# Altium schematic sheet 2_MISC.SchDoc(2_MISC)
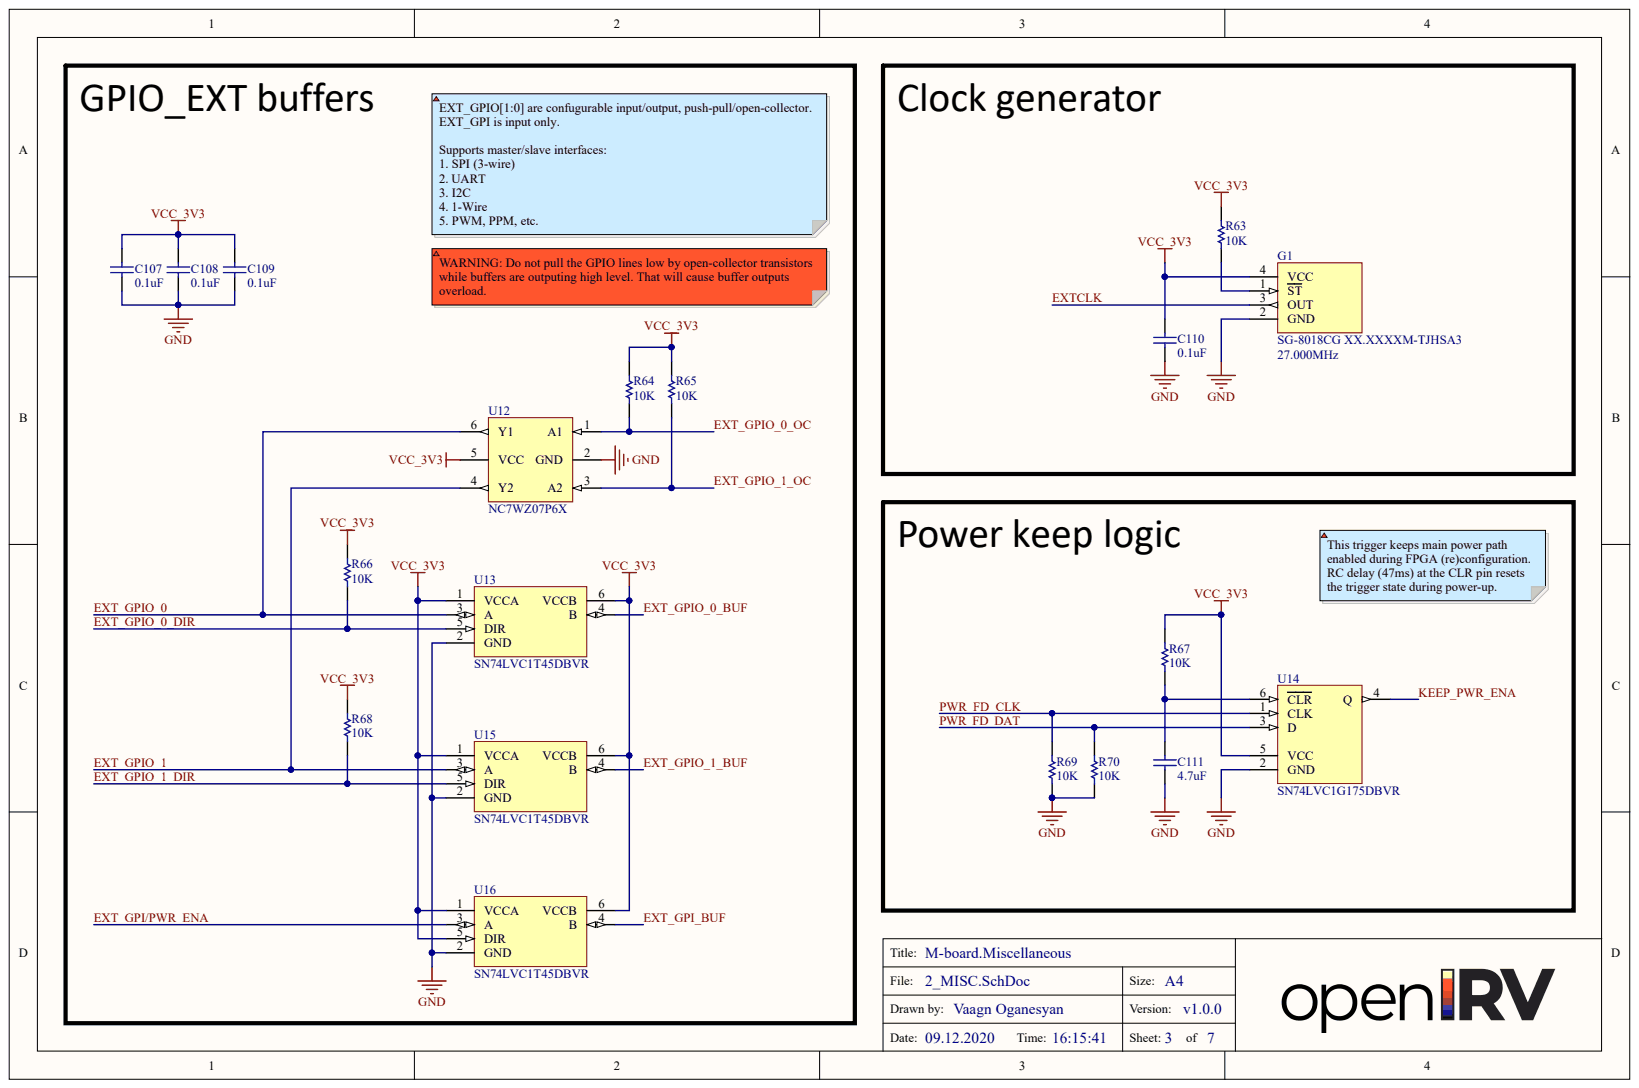
[diagram p0: 2_MISC.SchDoc]

## Parts
C107  0.1uF  [C0402[1005]]
C108  0.1uF  [C0402[1005]]
C109  0.1uF  [C0402[1005]]
C110  0.1uF  [C0402[1005]]
C111  4.7uF  [C0402[1005]]
G1  SG-8018CG XX.XXXXM-TJHSA3  Frequency: 27.000MHz  [SG-8018CG XX.XXXXM-TJHSA3]
R63  10K  [R0402[1005]]
R64  10K  [R0402[1005]]
R65  10K  [R0402[1005]]
R66  10K  [R0402[1005]]
R67  10K  [R0402[1005]]
R68  10K  [R0402[1005]]
R69  10K  [R0402[1005]]
R70  10K  [R0402[1005]]
U12  NC7WZ07P6X  [NC7WZ07P6X]
U13  SN74LVC1T45DBVR  [SN74LVC1T45DBVR]
U14  SN74LVC1G175DBVR  [SN74LVC1G175DBVR]
U15  SN74LVC1T45DBVR  [SN74LVC1T45DBVR]
U16  SN74LVC1T45DBVR  [SN74LVC1T45DBVR]

## Nets
GND: C107.2 C108.2 C109.2 C110.2 C111.2 G1.2(GND) R69.1 R70.1 U12.2(GND) U13.2(GND) U14.2(GND) U15.2(GND) U16.2(GND)
VCC_3V3: C107.1 C108.1 C109.1 C110.1 G1.4(VCC) R63.2 R64.2 R65.2 R66.2 R67.2 R68.2 U12.5(VCC) U13.1(VCCA) U13.6(VCCB) U14.5(VCC) U15.1(VCCA) U15.6(VCCB) U16.1(VCCA) U16.5(DIR) U16.6(VCCB)
EXT_GPI/PWR_ENA: U16.3(A)
EXT_GPI_BUF: U16.4(B)
EXT_GPIO_0: U12.6(Y1) U13.3(A)
EXT_GPIO_0_BUF: U13.4(B)
EXT_GPIO_0_DIR: R66.1 U13.5(DIR)
EXT_GPIO_0_OC: R64.1 U12.1(A1)
EXT_GPIO_1: U12.4(Y2) U15.3(A)
EXT_GPIO_1_BUF: U15.4(B)
EXT_GPIO_1_DIR: R68.1 U15.5(DIR)
EXT_GPIO_1_OC: R65.1 U12.3(A2)
EXTCLK: G1.3(OUT)
KEEP_PWR_ENA: U14.4(Q)
PWR_FD_CLK: R69.2 U14.1(CLK)
PWR_FD_DAT: R70.2 U14.3(D)
NetC111_1: C111.1 R67.1 U14.6(CLR)
NetG1_1: G1.1(ST) R63.1

## Library data of the parts
C107-C110 = CAP: Dielectric: X7R; Tolerance: 10%; Voltage: 50V; Component Kind: Standard; Library Name: general.IntLib; Mounting: SMD; Value: 0.1uF
C111 = CAP: Dielectric: X5R; Tolerance: 10%; Voltage: 6.3V; Component Kind: Standard; Library Name: general.IntLib; Mounting: SMD; Value: 4.7uF
G1 = SG-8018CG XX.XXXXM-TJHSA3: Oscillator; Component Kind: Standard; Library Name: general.IntLib; ManufacturerPartNumber: SG-8018CG XX.XXXXM-TJHSA3
R63-R70 = RES: Power: 0.06W; Tolerance: 5%; Component Kind: Standard; Library Name: general.IntLib; Mounting: SMD; Value: 10K
U12 = NC7WZ07P6X: Dual open-drain buffer; Component Kind: Standard; Library Name: general.IntLib
U13 U15 U16 = SN74LVC1T45DBVR: Single-Bit Dual-Supply Bus Transceiver; Component Kind: Standard; Library Name: general.IntLib
U14 = SN74LVC1G175DBVR: Component Kind: Standard; Library Name: general.IntLib
